# Altium schematic sheet LPEDT_revA1_bikeit_MCU_POWER_RESET.SchDoc(LPEDT_revA1_bikeit_MCU_POWER_RESET)
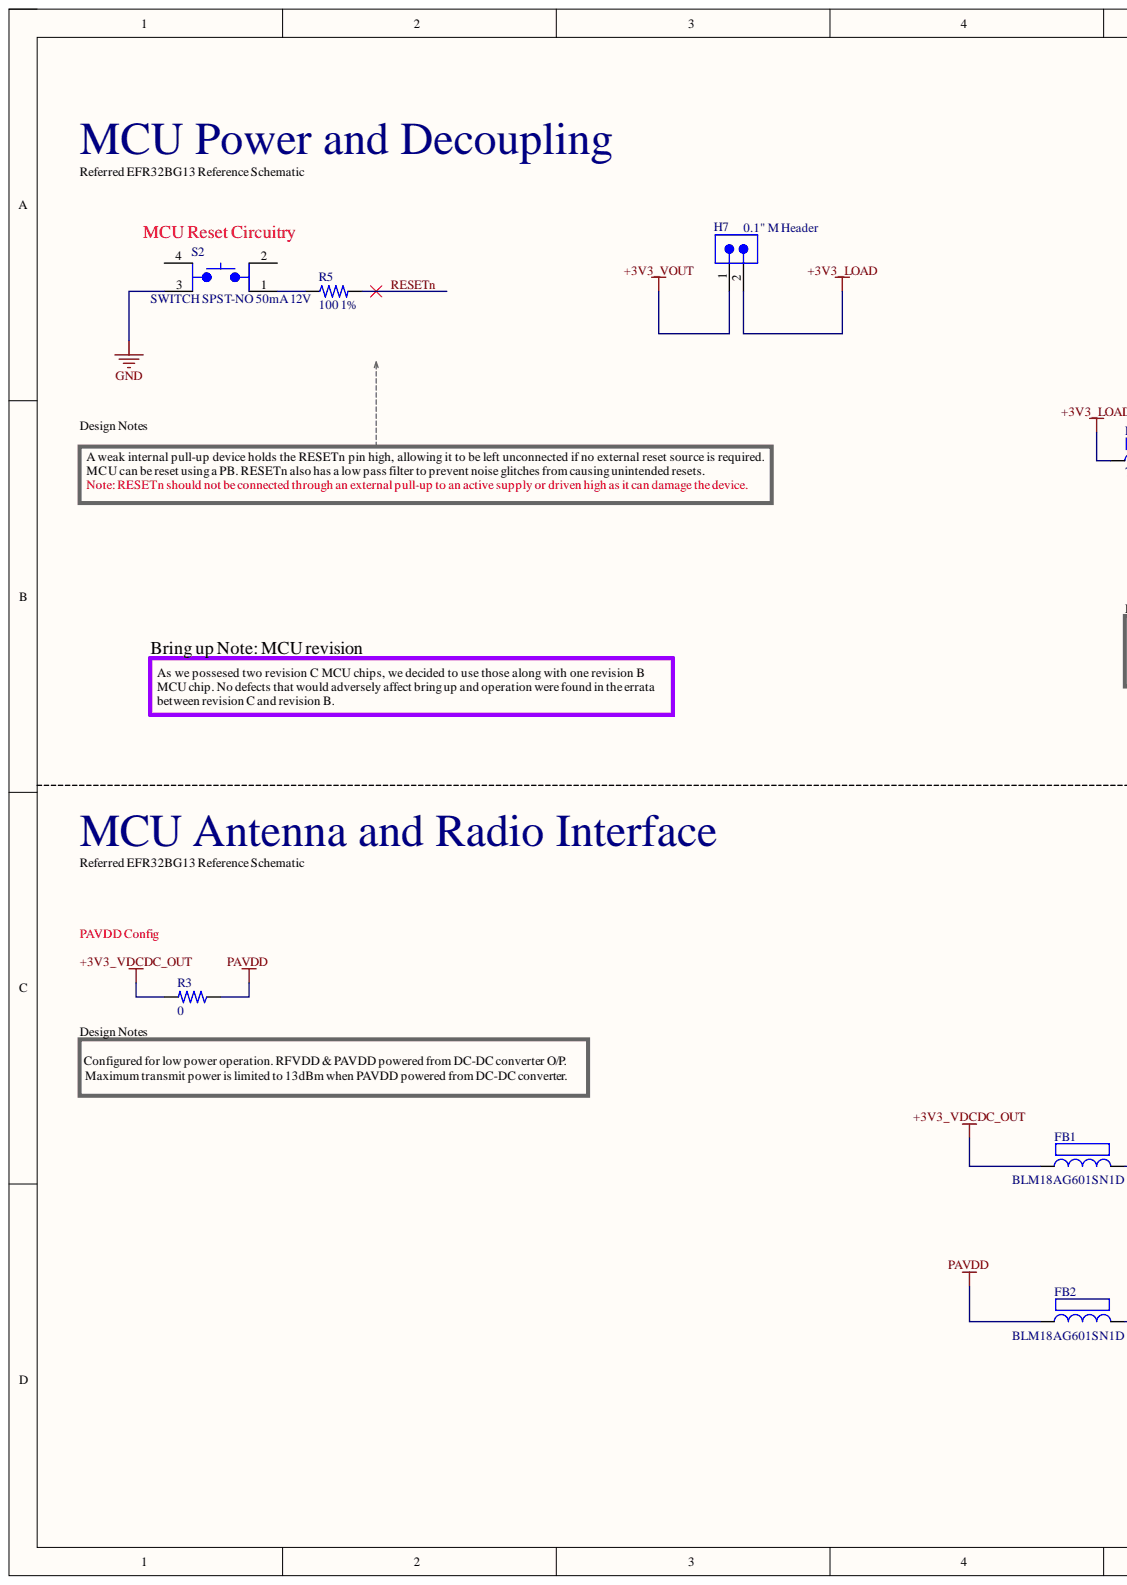
[diagram p0: LPEDT_revA1_bikeit_MCU_POWER_RESET.SchDoc, left]
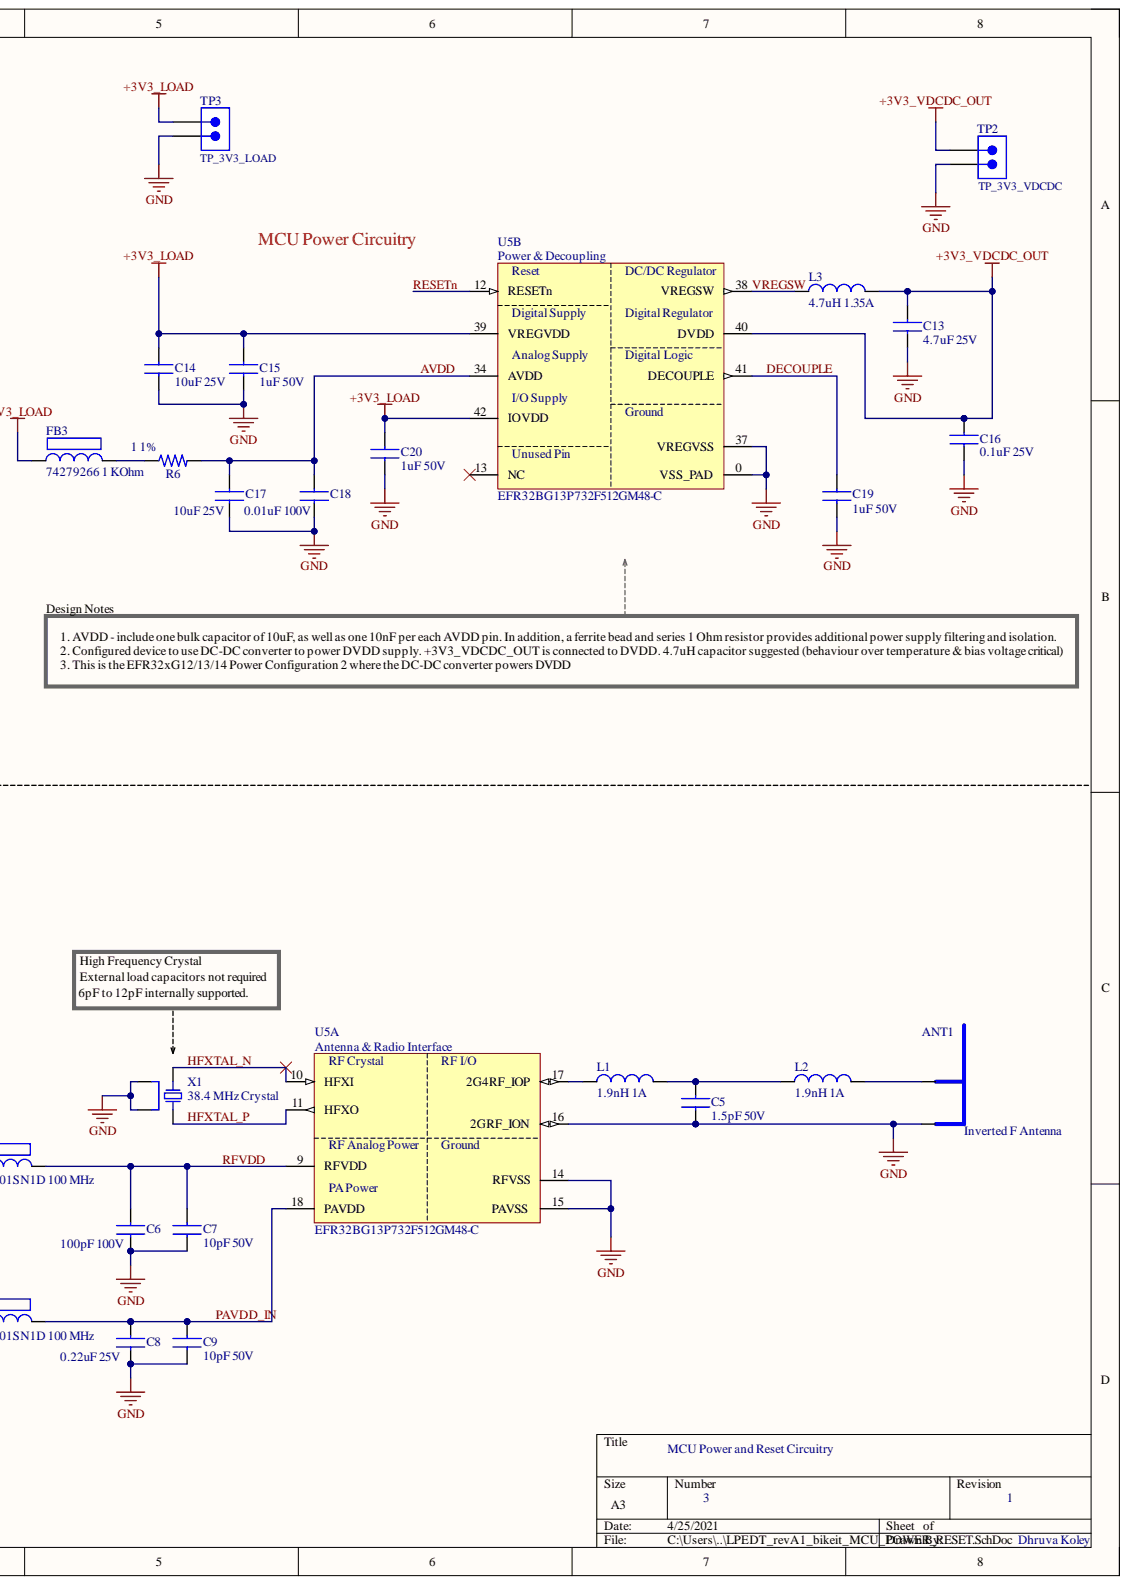
[diagram p1: LPEDT_revA1_bikeit_MCU_POWER_RESET.SchDoc, right]

## Parts
ANT1  Inverted F Antenna  [MIFA]
C5  1.5pF 50V  [CAP_0403_1.00mm*0.50mm]
C6  100pF 100V  Capacitance: 100pF  Voltage Rating: 100V  [CAP_0603_1.60mm*0.80mm]
C7  10pF 50V  Capacitance: 10pF  Voltage: 50V  [CAP_0603_1.60mm*0.80mm]
C8  0.22uF 25V  Voltage Rating: 25V  [CAP_0603_1.60mm*0.80mm]
C9  10pF 50V  Capacitance: 10pF  Voltage: 50V  [CAP_0603_1.60mm*0.80mm]
C13  4.7uF 25V  Capacitance: 4.7uF  [CAP_0603_1.60mm*0.80mm]
C14  10uF 25V  Capacitance: 10uF  [CAP_0805_2MM]
C15  1uF 50V  Capacitance: 1uF  Voltage Rating: 50V  [CAP_0603_1.60mm*0.80mm]
C16  0.1uF 25V  [CAP_0603_1.60mm*0.80mm]
C17  10uF 25V  Capacitance: 10uF  Voltage Rating: 25V  [CAP_0805_2MM]
C18  0.01uF 100V  Voltage: 100V  [CAP_0603_1.60mm*0.80mm]
C19  1uF 50V  Capacitance: 1uF  Voltage Rating: 50V  [CAP_0603_1.60mm*0.80mm]
C20  1uF 50V  Capacitance: 1uF  Voltage Rating: 50V  [CAP_0603_1.60mm*0.80mm]
FB1  BLM18AG601SN1D 100 MHz  [FB_Chip2PinSM127P600-8N]
FB2  BLM18AG601SN1D 100 MHz  [FB_Chip2PinSM127P600-8N]
FB3  74279266 1 KOhm  [FB_0603_1.60mm*0.80mm]
H7  0.1" M Header  [2-PIN_MALE_HEADER_01-IN]
L1  1.9nH 1A  [IND_0402]
L2  1.9nH 1A  [IND_0402]
L3  4.7uH 1.35A  Current Rating: 1.35A  Inductance: 4.7uH  [IND_WE-TPC 2828]
R3  0  [RES_C1005X04N]
R5  100 1%  Tolerance: 1%  [RES_0603_1608X06]
R6  1 1%  Resistance Tolerance: 1%  [RES_0603_1608X06]
S2  SWITCH SPST-NO 50mA 12V  [SW_TL3305AF260QG]
TP2  TP_3V3_VDCDC  [TP10x_Probe]
TP3  TP_3V3_LOAD  [TP10x_Probe]
U5  EFR32BG13P732F512GM48-C  [MCU_QFN50P700X700X90_HS-49N]  (parts A,B)
X1  38.4 MHz Crystal  [SMD_4 CRYSTAL]

## Nets
+3V3_LOAD: C14.1 C15.1 C20.1 FB3.1 H7.2 TP3.1 U5.39(VREGVDD) U5.42(IOVDD)
+3V3_VDCDC_OUT: C13.1 C16.1 FB1.1 L3.2 R3.2 TP2.1 U5.40(DVDD)
+3V3_VOUT: H7.1
GND: ANT1.GND C5.2 C6.2 C7.2 C8.2 C9.2 C13.2 C14.2 C15.2 C16.2 C17.2 C18.2 C19.2 C20.2 S2.3 TP2.2 TP3.2 U5.0(VSS_PAD) U5.14(RFVSS) U5.15(PAVSS) U5.16(2GRF_ION) U5.37(VREGVSS) X1.2 X1.4
PAVDD: FB2.1 R3.1
AVDD: C17.1 C18.1 R6.2 U5.34(AVDD)
DECOUPLE: C19.1 U5.41(DECOUPLE)
HFXTAL_N: U5.10(HFXI) X1.3
HFXTAL_P: U5.11(HFXO) X1.1
PAVDD_IN: C8.1 C9.1 FB2.2 U5.18(PAVDD)
RESETn: R5.2 U5.12(RESETn)
RFVDD: C6.1 C7.1 FB1.2 U5.9(RFVDD)
VREGSW: L3.1 U5.38(VREGSW)
NetANT1_FEED: ANT1.FEED L2.2
NetC5_1: C5.1 L1.2 L2.1
NetFB3_2: FB3.2 R6.1
NetL1_1: L1.1 U5.17(2G4RF_IOP)
NetR5_1: R5.1 S2.1

Not connected: S2 2 4; U5 13(NC)

## Library data of the parts
ANT1 = Inverted F Antenna: Inverted F antenna for BT; Component Kind: Net Tie; Library Name: LPEDT_revA1_bikeit_IL.IntLib
C5 = 500R07S1R5BV4T: 1.5pF ±0.1pF 50V Ceramic Capacitor C0G, NP0 0402 (1005 Metric); Manufacturer: Johanson Technology Inc.; Component Kind: Standard; Manufacturer Part Number: 500R07S1R5BV4T; RoHS Compliant: Yes; Supplier 1: Digi-Key; Supplier Part Number 1: 712-1270-1-ND; Library Name: LPEDT_revA1_bikeit_IL.IntLib
C6 = GRM1885C2A101FA01D: Multilayer Ceramic Capacitors MLCC - SMD/SMT 100pF 100Volts C0G 0.01; Manufacturer: Murata; Tolerance: 1%; Case Code (Imperial): 0603; Case Code (Metric): 1608; Case/Package: 0603; Category: Ceramic Capacitors; Component Kind: Standard; ComponentLink1Description: https://datasheet.ciiva.com/29537/partnumbering-e-01-29537864.pdf?src-supplier=Digi-Key; ComponentLink1URL: https://datasheet.ciiva.com/29537/partnumbering-e-01-29537864.pdf?src-supplier=Digi-Key; Depth: 0.8mm; Dielectric: C0G; Height: 0.8mm; Length: 1.6mm; Manufacturer Part Number: GRM1885C2A101FA01D; Max Operating Temperature: 125°C; Min Operating Temperature: -55°C; Mount: Surface Mount; Package Quantity: 4000; Packaging: Tape and Reel; Pricing 1: 4000=0.03696, 8000=0.03511, 12000=0.03326, 28000=0.03142 (USD); Radiation Hardening: No; RoHS: Yes; Stock 1: 40000; Supplier 1: Digi-Key; Supplier Part Number 1: 490-14623-1-ND; Thickness: 0.035inch; Voltage Rating (DC): 100V; Width: 0.031inch; Library Name: LPEDT_revA1_bikeit_IL.IntLib
C7 C9 = GRM1885C1H100FA01D: Cap Ceramic 10pF 50V C0G 1% SMD 0603 125C T/R; Manufacturer: Murata; Temperature Coefficient: 30ppm/°C; Case Code (Imperial): 0603; Case Code (Metric): 1608; Case/Package: 0603; Category: Ceramic Capacitors; Component Kind: Standard; ComponentLink1Description: https://datasheet.ciiva.com/2989/c02e-2989424.pdf?src-supplier=Avnet; ComponentLink1URL: https://datasheet.ciiva.com/2989/c02e-2989424.pdf?src-supplier=Avnet; ComponentLink2Description: https://datasheet.ciiva.com/166/partnumbering-e-01-166098.pdf?src-supplier=Digi-Key; ComponentLink2URL: https://datasheet.ciiva.com/166/partnumbering-e-01-166098.pdf?src-supplier=Digi-Key; ComponentLink3Description: https://datasheet.ciiva.com/45259/partnumbering-e-01-45259517.pdf?src-supplier=Digi-Key; ComponentLink3URL: https://datasheet.ciiva.com/45259/partnumbering-e-01-45259517.pdf?src-supplier=Digi-Key; ComponentLink4Description: https://datasheet.ciiva.com/17453/c02e-17453134.pdf?src-supplier=Avnet+Europe; ComponentLink4URL: https://datasheet.ciiva.com/17453/c02e-17453134.pdf?src-supplier=Avnet+Europe; Construction: Flat; Depth: 0.8mm; Dielectric: C0G; Height: 0.8mm; Lead Free: Lead Free; Length: 1.6mm; Manufacturer Part Number: GRM1885C1H100FA01D; Material: Ceramic; Max Operating Temperature: 125°C; Min Operating Temperature: -55°C; Mount: Surface Mount; Package Quantity: 4000; Packaging: Tape and Reel; Pricing 1: 1=0.15000, 10=0.11000, 50=0.06240, 100=0.05170 (USD); Radiation Hardening: No; RoHS: Yes; RoHS Compliant: Yes; Series: GRM; Stock 1: 160; Supplier 1: Digi-Key; Supplier Part Number 1: 490-9713-1-ND; Termination: SMD/SMT; Thickness: 0.035inch; Tolerance: 1%; Voltage Rating: 50V; Voltage Rating (DC): 50V; Width: 0.8mm; Library Name: LPEDT_revA1_bikeit_IL.IntLib
C8 = GRM188R71E224KA88D: Capacitor 220 nF 25 VDC PU=4000 ST, GRM188R71E224KA88D, Murata; Capacitance: 220nF; Dielectric: X7R; Material: Ceramic; Tolerance: 10%; Voltage: 50V; Case Code (Imperial): 0603; Case Code (Metric): 1608; Case/Package: 0603; Category: Ceramic Capacitors; Component Kind: Standard; ComponentLink1Description: https://datasheet.ciiva.com/5411/product-5411362.pdf?src-supplier=Mouser; ComponentLink1URL: https://datasheet.ciiva.com/5411/product-5411362.pdf?src-supplier=Mouser; ComponentLink2Description: https://datasheet.ciiva.com/11786/0900766b807a1cab-11786308.pdf?src-supplier=RSComponents; ComponentLink2URL: https://datasheet.ciiva.com/11786/0900766b807a1cab-11786308.pdf?src-supplier=RSComponents; ComponentLink3Description: https://datasheet.ciiva.com/6068/1784408-6068393.pdf?src-supplier=Farnell; ComponentLink3URL: https://datasheet.ciiva.com/6068/1784408-6068393.pdf?src-supplier=Farnell; ComponentLink4Description: https://datasheet.ciiva.com/133/grm188r71e224ka88-01-133883.pdf?src-supplier=Digi-Key; ComponentLink4URL: https://datasheet.ciiva.com/133/grm188r71e224ka88-01-133883.pdf?src-supplier=Digi-Key; ComponentLink5Description: https://datasheet.ciiva.com/133/grm188r71e224ka88-01-133884.pdf?src-supplier=Digi-Key; ComponentLink5URL: https://datasheet.ciiva.com/133/grm188r71e224ka88-01-133884.pdf?src-supplier=Digi-Key; ComponentLink6Description: https://datasheet.ciiva.com/3777/1531grm188r71e224ka8823-3777688.pdf?src-supplier=Arrow+Electronics; ComponentLink6URL: https://datasheet.ciiva.com/3777/1531grm188r71e224ka8823-3777688.pdf?src-supplier=Arrow+Electronics; ComponentLink7Description: https://datasheet.ciiva.com/29202/451619-da-01-en-vs-kondensator-0603-29202002.pdf?src-supplier=Conrad; ComponentLink7URL: https://datasheet.ciiva.com/29202/451619-da-01-en-vs-kondensator-0603-29202002.pdf?src-supplier=Conrad; ComponentLink8Description: https://datasheet.ciiva.com/14116/nmgrm-data-en-14116629.pdf?src-supplier=Distrelec; ComponentLink8URL: https://datasheet.ciiva.com/14116/nmgrm-data-en-14116629.pdf?src-supplier=Distrelec; ComponentLink9Description: https://datasheet.ciiva.com/16425/product-16425866.pdf?src-supplier=Future+Electronics; ComponentLink9URL: https://datasheet.ciiva.com/16425/product-16425866.pdf?src-supplier=Future+Electronics; ComponentLink10Description: https://datasheet.ciiva.com/22703/1784408-22703338.pdf?src-supplier=Element14; ComponentLink10URL: https://datasheet.ciiva.com/22703/1784408-22703338.pdf?src-supplier=Element14; ComponentLink11Description: https://datasheet.ciiva.com/7937/1784408-7937645.pdf?src-supplier=Element14; ComponentLink11URL: https://datasheet.ciiva.com/7937/1784408-7937645.pdf?src-supplier=Element14; ComponentLink12Description: https://datasheet.ciiva.com/48436/nmgrm-data-en-48436687.pdf?src-supplier=Distrelec; ComponentLink12URL: https://datasheet.ciiva.com/48436/nmgrm-data-en-48436687.pdf?src-supplier=Distrelec; Depth: 0.8mm; Height: 0.8mm; Lead Free: Lead Free; Length: 1.6mm; Manufacturer: Murata; Manufacturer Part Number: GRM188R71E224KA88D; Max Operating Temperature: 125°C; Min Operating Temperature: -55°C; Mount: Surface Mount; Number of Pins: 2; Package Quantity: 1; Packaging: Tape and Reel; Pricing 1: 1=0.20000, 10=0.13700, 50=0.08240, 100=0.06960, 500=0.04760, 1000=0.04210 (USD); Radiation Hardening: No; REACH SVHC: No SVHC; RoHS: Yes; RoHS Compliant: Yes; Stock 1: 208118; Supplier 1: Digi-Key; Supplier Part Number 1: 490-3290-1-ND; Termination: SMD/SMT; Thickness: 0.035inch; Voltage Rating (DC): 25V; Width: 0.8mm; Library Name: LPEDT_revA1_bikeit_IL.IntLib
C13 = GRM188R61E475KE11D: Cap Ceramic 0603 4.7 uF 25 V ±10 % Tolerance X5R SMT Multilayer Ceramic Capacitor; Manufacturer: Murata; Tolerance: 10%; Case Code (Imperial): 0603; Case Code (Metric): 1608; Case/Package: 0603; Category: Ceramic Capacitors; Component Kind: Standard; ComponentLink1Description: https://datasheet.ciiva.com/46444/1769893-46444982.pdf?src-supplier=Element14; ComponentLink1URL: https://datasheet.ciiva.com/46444/1769893-46444982.pdf?src-supplier=Element14; ComponentLink2Description: https://datasheet.ciiva.com/46444/1769893-46444983.pdf?src-supplier=Element14; ComponentLink2URL: https://datasheet.ciiva.com/46444/1769893-46444983.pdf?src-supplier=Element14; ComponentLink3Description: https://datasheet.ciiva.com/14891/partnumbering-e-01-14891103.pdf?src-supplier=Digi-Key; ComponentLink3URL: https://datasheet.ciiva.com/14891/partnumbering-e-01-14891103.pdf?src-supplier=Digi-Key; ComponentLink4Description: https://datasheet.ciiva.com/14891/partnumbering-e-01-14891102.pdf?src-supplier=Digi-Key; ComponentLink4URL: https://datasheet.ciiva.com/14891/partnumbering-e-01-14891102.pdf?src-supplier=Digi-Key; Depth: 0.8mm; Dielectric: X5R; Height: 0.8mm; Lead Free: Lead Free; Length: 1.6mm; Manufacturer Part Number: GRM188R61E475KE11D; Material: Ceramic; Max Operating Temperature: 85°C; Min Operating Temperature: -55°C; Mount: Surface Mount; Package Quantity: 4000; Packaging: Cut Tape; Pricing 1: 1=0.36000, 10=0.24700, 50=0.17280, 100=0.14820, 500=0.11112, 1000=0.09384 (USD); REACH SVHC: No SVHC; RoHS: Yes; RoHS Compliant: Yes; Series: GRM; Stock 1: 307528; Supplier 1: Digi-Key; Supplier Part Number 1: 490-7203-1-ND; Thickness: 0.031inch; Voltage: 25V; Voltage Rating: 25V; Voltage Rating (DC): 25V; Width: 0.031inch; Library Name: LPEDT_revA1_bikeit_IL.IntLib
C14 C17 = GRM21BR61E106KA73K: CAP CER 10UF 25V X5R 0805; Manufacturer: Murata; Tolerance: 10%; Case/Package: 0805; Category: Ceramic Capacitors; Component Kind: Standard; ComponentLink1Description: http://www.mouser.com/ds/2/281/c02e-2905.pdf?src-supplier=Mouser; ComponentLink1URL: http://www.mouser.com/ds/2/281/c02e-2905.pdf?src-supplier=Mouser; ComponentLink2Description: http://search.murata.co.jp/Ceramy/image/img/A01X/G101/ENG/GRM21BR61E106KA73-01.pdf?src-supplier=Digi-Key; ComponentLink2URL: http://search.murata.co.jp/Ceramy/image/img/A01X/G101/ENG/GRM21BR61E106KA73-01.pdf?src-supplier=Digi-Key; ComponentLink3Description: https://search.murata.co.jp/Ceramy/image/img/A01X/G101/ENG/GRM21BR61E106KA73-01.pdf?src-supplier=Digi-Key; ComponentLink3URL: https://search.murata.co.jp/Ceramy/image/img/A01X/G101/ENG/GRM21BR61E106KA73-01.pdf?src-supplier=Digi-Key; Dielectric: X5R; Length: 0.079inch; Manufacturer Part Number: GRM21BR61E106KA73K; Max Operating Temperature: 85°C; Min Operating Temperature: -55°C; Mount: Surface Mount; Package Quantity: 10000; Packaging: Tape and Reel; Pricing 1: 1=0.23000, 10=0.15700, 50=0.09400, 100=0.07940, 500=0.05434, 1000=0.04807, 2500=0.04598, 5000=0.04180 (USD); RoHS: Yes; RoHS Compliant: Yes; Stock 1: 79269; Supplier 1: Digi-Key; Supplier Part Number 1: 490-16824-1-ND; Thickness: 0.055inch; Voltage Rating (DC): 25V; Weight: 0.000335oz; Width: 0.049inch; Library Name: LPEDT_revA1_bikeit_IL.IntLib
  C14: Voltage Rating: 25V
C15 C19 C20 = GRT188R61H105KE13D: CAP, MLCC, AEC-Q200, X5R, 1uF, 50V, 0603; Manufacturer: Murata; Tolerance: 10%; Case Code (Imperial): 0603; Case Code (Metric): 1608; Case/Package: 0603; Category: Ceramic Capacitors; Component Kind: Standard; ComponentLink1Description: http://www.farnell.com/datasheets/2165959.pdf?src-supplier=Farnell; ComponentLink1URL: http://www.farnell.com/datasheets/2165959.pdf?src-supplier=Farnell; ComponentLink2Description: http://search.murata.co.jp/Ceramy/image/img/A01X/G101/ENG/GRT188R61H105KE13-01.pdf?src-supplier=Digi-Key; ComponentLink2URL: http://search.murata.co.jp/Ceramy/image/img/A01X/G101/ENG/GRT188R61H105KE13-01.pdf?src-supplier=Digi-Key; ComponentLink3Description: https://search.murata.co.jp/Ceramy/image/img/A01X/G101/ENG/GRT188R61H105KE13-01.pdf?src-supplier=Digi-Key; ComponentLink3URL: https://search.murata.co.jp/Ceramy/image/img/A01X/G101/ENG/GRT188R61H105KE13-01.pdf?src-supplier=Digi-Key; ComponentLink4Description: http://www.farnell.com/datasheets/2165959.pdf?src-supplier=Newark; ComponentLink4URL: http://www.farnell.com/datasheets/2165959.pdf?src-supplier=Newark; Dielectric: X5R; Length: 0.063inch; Manufacturer Part Number: GRT188R61H105KE13D; Max Operating Temperature: 85°C; Min Operating Temperature: -55°C; Mount: Surface Mount; Packaging: Tape and Reel; Pricing 1: 1=0.26000, 10=0.17900, 50=0.10720, 100=0.09060, 500=0.06198, 1000=0.05483 (USD); Ratings: AEC-Q200; RoHS: Yes; RoHS Compliant: Yes; Stock 1: 645268; Supplier 1: Digi-Key; Supplier Part Number 1: 490-12330-1-ND; Thickness: 0.035inch; Voltage Rating (DC): 50V; Width: 0.031inch; Library Name: LPEDT_revA1_bikeit_IL.IntLib
C16 = GCJ188R71E104KA12D: CAP CER 0.1UF 25V X7R 0603; Manufacturer: Murata; Capacitance: 100nF; Tolerance: 10%; Case Code (Imperial): 0603; Case Code (Metric): 1608; Case/Package: 0603; Category: Ceramic Capacitors; Component Kind: Standard; ComponentLink1Description: http://search.murata.co.jp/Ceramy/image/img/A01X/G101/ENG/GCJ188R71E104KA12-01.pdf?src-supplier=Digi-Key; ComponentLink1URL: http://search.murata.co.jp/Ceramy/image/img/A01X/G101/ENG/GCJ188R71E104KA12-01.pdf?src-supplier=Digi-Key; Features: Soft Termination; Manufacturer Part Number: GCJ188R71E104KA12D; Max Operating Temperature: 125°C; Min Operating Temperature: -55°C; Mount: Surface Mount; Packaging: Tape and Reel; Pricing 1: 1=0.21000, 10=0.14900, 50=0.08480, 100=0.07030, 500=0.04928, 1000=0.04080 (USD); Ratings: AEC-Q200; RoHS: Yes; RoHS Compliant: Yes; Stock 1: 1672; Supplier 1: Mouser; Supplier Part Number 1: 81-GCJ188R71E104KA2D; Voltage Rating: 25V; Library Name: LPEDT_revA1_bikeit.SchLib
C18 = GCM188R72A103KA37D: Multilayer Ceramic Capacitor, AEC-Q200 GCM Series, 0.01 F, 10%, X7R, 100 V RoHS Compliant: Yes; Manufacturer: Murata; Manufacturer Part Number: GCM188R72A103KA37J; Capacitance: 10nF; Case Code (Imperial): 0603; Case Code (Metric): 1608; Case/Package: 0603; Category: Ceramic Capacitors; Component Kind: Standard; ComponentLink1Description: https://datasheet.ciiva.com/132/product-132907.pdf?src-supplier=Digi-Key; ComponentLink1URL: https://datasheet.ciiva.com/132/product-132907.pdf?src-supplier=Digi-Key; ComponentLink2Description: https://datasheet.ciiva.com/132/product-132909.pdf?src-supplier=Digi-Key; ComponentLink2URL: https://datasheet.ciiva.com/132/product-132909.pdf?src-supplier=Digi-Key; ComponentLink3Description: https://datasheet.ciiva.com/16497/product-16497251.pdf?src-supplier=Future+Electronics; ComponentLink3URL: https://datasheet.ciiva.com/16497/product-16497251.pdf?src-supplier=Future+Electronics; ComponentLink4Description: https://datasheet.ciiva.com/18183/getdatasheetpartid-178890-18183651.pdf?src-supplier=Verical; ComponentLink4URL: https://datasheet.ciiva.com/18183/getdatasheetpartid-178890-18183651.pdf?src-supplier=Verical; ComponentLink5Description: https://datasheet.ciiva.com/132/product-132908.pdf?src-supplier=Digi-Key; ComponentLink5URL: https://datasheet.ciiva.com/132/product-132908.pdf?src-supplier=Digi-Key; ComponentLink6Description: https://datasheet.ciiva.com/11786/0900766b80ef92d0-11786108.pdf?src-supplier=RSComponents; ComponentLink6URL: https://datasheet.ciiva.com/11786/0900766b80ef92d0-11786108.pdf?src-supplier=RSComponents; ComponentLink7Description: https://datasheet.ciiva.com/11619/product-11619719.pdf?src-supplier=Avnet; ComponentLink7URL: https://datasheet.ciiva.com/11619/product-11619719.pdf?src-supplier=Avnet; Construction: Flat; Depth: 0.8mm; Dielectric: X7R; Height: 0.8mm; Lead Free: Lead Free; Length: 1.6mm; Max Operating Temperature: 125°C; Min Operating Temperature: -55°C; Mount: Surface Mount; Package Quantity: 1; Packaging: Tape and Reel; Pricing 1: 1=0.10000, 10=0.07400, 50=0.04060, 100=0.03310, 500=0.02364, 1000=0.01857 (USD); Radiation Hardening: No; Ratings: AEC-Q200; REACH SVHC: No SVHC; RoHS: Yes; RoHS Compliant: Yes; Series: GCM; Stock 1: 112779; Supplier 1: Digi-Key; Supplier Part Number 1: 490-8028-1-ND; Termination: SMD/SMT; Thickness: 0.035inch; Tolerance: 10%; Voltage Rating: 100V; Voltage Rating (DC): 100V; Width: 0.8mm; Library Name: LPEDT_revA1_bikeit.SchLib
FB1 FB2 = BLM18AG601SN1D: Ferrite Bead, 0603 / 1608, 600 ohm, 500 mA, Série BLM18A, 0.38 ohm, ± 25%; Manufacturer: Murata; Current Rating: 500mA; DC Resistance (DCR): 380mR; Frequency: 100MHz; Impedance: 600R; Material: Steel,Ferrite; Number of Circuits: 1; Shielding: Unshielded; Case Code (Imperial): 0603; Case Code (Metric): 1608; Case/Package: 0603; Category: Inductors; Component Kind: Standard; ComponentLink1Description: https://datasheet.ciiva.com/19460/1844766-19460213.pdf?src-supplier=Jameco; ComponentLink1URL: https://datasheet.ciiva.com/19460/1844766-19460213.pdf?src-supplier=Jameco; ComponentLink2Description: https://datasheet.ciiva.com/29347/442309-da-01-en-chip-drossel-0603-600r-500ma-1-6x0-8mm-29347900.pdf?src-supplier=Conrad; ComponentLink2URL: https://datasheet.ciiva.com/29347/442309-da-01-en-chip-drossel-0603-600r-500ma-1-6x0-8mm-29347900.pdf?src-supplier=Conrad; ComponentLink3Description: https://datasheet.ciiva.com/41449/001172228-da-01-en-drossel-0603-600r-1-6x0-8mm-4000st-41449517.pdf?src-supplier=Conrad; ComponentLink3URL: https://datasheet.ciiva.com/41449/001172228-da-01-en-drossel-0603-600r-1-6x0-8mm-4000st-41449517.pdf?src-supplier=Conrad; ComponentLink4Description: https://datasheet.ciiva.com/1012/l0110s0100blm18a-1012017.pdf?src-supplier=Digi-Key; ComponentLink4URL: https://datasheet.ciiva.com/1012/l0110s0100blm18a-1012017.pdf?src-supplier=Digi-Key; ComponentLink5Description: https://datasheet.ciiva.com/1012/l0110s0100blm18a-1012018.pdf?src-supplier=Digi-Key; ComponentLink5URL: https://datasheet.ciiva.com/1012/l0110s0100blm18a-1012018.pdf?src-supplier=Digi-Key; ComponentLink6Description: https://datasheet.ciiva.com/1012/l0110s0100blm18a-1012019.pdf?src-supplier=Digi-Key; ComponentLink6URL: https://datasheet.ciiva.com/1012/l0110s0100blm18a-1012019.pdf?src-supplier=Digi-Key; ComponentLink7Description: https://datasheet.ciiva.com/1466/1756147-1466933.pdf?src-supplier=Element14; ComponentLink7URL: https://datasheet.ciiva.com/1466/1756147-1466933.pdf?src-supplier=Element14; ComponentLink8Description: https://datasheet.ciiva.com/1466/1756147-1466934.pdf?src-supplier=Element14; ComponentLink8URL: https://datasheet.ciiva.com/1466/1756147-1466934.pdf?src-supplier=Element14; ComponentLink9Description: https://datasheet.ciiva.com/16210/l0110s0100blm18a-16210006.pdf?src-supplier=Future+Electronics; ComponentLink9URL: https://datasheet.ciiva.com/16210/l0110s0100blm18a-16210006.pdf?src-supplier=Future+Electronics; ComponentLink10Description: https://datasheet.ciiva.com/3081/l0110s0100blm18a-3081613.pdf?src-supplier=Avnet; ComponentLink10URL: https://datasheet.ciiva.com/3081/l0110s0100blm18a-3081613.pdf?src-supplier=Avnet; Core Material: Ferrite; DC Current: 500mA; Depth: 0.8mm; Height: 0.8mm; Lead Free: Lead Free; Length: 1.6mm; Manufacturer Part Number: BLM18AG601SN1D; Max Current Rating: 500mA; Max DC Current: 500mA; Max Operating Temperature: 125°C; Military Standard: Not; Min Operating Temperature: -55°C; Number of Lines: 1; Number of Pins: 2; Package Quantity: 1; Packaging: Tape and Reel; Pricing 1: 1=0.10000, 10=0.07200, 25=0.06560, 50=0.05900, 100=0.04320, 250=0.03144, 500=0.02882, 1000=0.02489 (USD); Radiation Hardening: No; REACH SVHC: No SVHC; RoHS: Yes; RoHS Compliant: Yes; Stock 1: 826617; Supplier 1: Digi-Key; Supplier Part Number 1: 490-1014-1-ND; Termination: SMD/SMT; Test Frequency: 100MHz; Tolerance: 25%; Weight: 7.1E-05oz; Width: 0.8mm; Library Name: LPEDT_revA1_bikeit_IL.IntLib
FB3 = 74279266: Ferrite Bead, 0603 [1608 Metric], 1 kohm, 200 mA, WE-CBF Series, 0.6 ohm, ± 25%; Manufacturer: Wurth Electronics; Current Rating: 200mA; DC Resistance (DCR): 600mR; Impedance: 1kR; Inductance: 1.8nH; Number of Lines: 1; Shielding: Unshielded; Test Frequency: 100MHz; Case Code (Imperial): 0603; Case Code (Metric): 1608; Case/Package: SMD/SMT; Category: Ferrite Beads and Chips; Component Kind: Standard; ComponentLink1Description: https://datasheet.ciiva.com/14634/0900766b801ef1d0-14634816.pdf?src-supplier=Allied+Electronics; ComponentLink1URL: https://datasheet.ciiva.com/14634/0900766b801ef1d0-14634816.pdf?src-supplier=Allied+Electronics; ComponentLink2Description: https://datasheet.ciiva.com/23763/74279266-23763009.pdf?src-supplier=Newark; ComponentLink2URL: https://datasheet.ciiva.com/23763/74279266-23763009.pdf?src-supplier=Newark; ComponentLink3Description: https://datasheet.ciiva.com/11799/0900766b801ef1d0-11799352.pdf?src-supplier=RSComponents; ComponentLink3URL: https://datasheet.ciiva.com/11799/0900766b801ef1d0-11799352.pdf?src-supplier=RSComponents; ComponentLink4Description: https://datasheet.ciiva.com/14971/74279266-14971192.pdf?src-supplier=Digi-Key; ComponentLink4URL: https://datasheet.ciiva.com/14971/74279266-14971192.pdf?src-supplier=Digi-Key; ComponentLink5Description: https://datasheet.ciiva.com/12395/74279266-221135-12395453.pdf?src-supplier=Mouser; ComponentLink5URL: https://datasheet.ciiva.com/12395/74279266-221135-12395453.pdf?src-supplier=Mouser; ComponentLink6Description: https://datasheet.ciiva.com/1466/1705901-1466509.pdf?src-supplier=Element14; ComponentLink6URL: https://datasheet.ciiva.com/1466/1705901-1466509.pdf?src-supplier=Element14; ComponentLink7Description: https://datasheet.ciiva.com/22711/1705901-22711422.pdf?src-supplier=Element14; ComponentLink7URL: https://datasheet.ciiva.com/22711/1705901-22711422.pdf?src-supplier=Element14; ComponentLink8Description: https://datasheet.ciiva.com/48698/001087059-da-01-ml-we-cbf-smd-ferrit-74279266-de-en-48698427.pdf?src-supplier=Conrad; ComponentLink8URL: https://datasheet.ciiva.com/48698/001087059-da-01-ml-we-cbf-smd-ferrit-74279266-de-en-48698427.pdf?src-supplier=Conrad; Core Material: Ferrite; Depth: 0.8mm; Height: 0.8mm; Lead Free: Lead Free; Length: 1.6mm; Manufacturer Part Number: 74279266; Max Current Rating: 200mA; Max DC Current: 200mA; Max Operating Temperature: 125°C; Min Operating Temperature: -55°C; Packaging: Tape and Reel; Pricing 1: 1=0.17000, 50=0.16800, 100=0.15500, 500=0.14800, 1000=0.14100, 2000=0.13400 (USD); REACH SVHC: Unknown; RoHS: Yes; RoHS Compliant: Yes; Series: WE-CBF; Stock 1: 55585; Supplier 1: Digi-Key; Supplier Part Number 1: 732-4484-1-ND; Termination: SMD/SMT; Tolerance: 25%; Width: 0.8mm; Library Name: LPEDT_revA1_bikeit_IL.IntLib
H7 = PRPC002SAAN-RC: CONN HEADER VERT 2POS 2.54MM; Manufacturer: Sullins; Connector Type: Header, Unshrouded, Breakaway; Number of Positions: 2; Number of Positions Loaded: All; Online Catalog: 0.100" (2.54mm) Pitch Connector Headers; Other Names: S9510E-02  S9510E-02-ND; Pitch: 0.100" (2.54mm); Category: Connectors, Interconnects; Color: Black; Component Kind: Standard; ComponentLink1Description: https://media.digikey.com/PDF/Data%20Sheets/Sullins%20PDFs/xRxCzzzSxxN-RC_ST_11635-B.pdf?src-supplier=Digi-Key; ComponentLink1URL: https://media.digikey.com/PDF/Data%20Sheets/Sullins%20PDFs/xRxCzzzSxxN-RC_ST_11635-B.pdf?src-supplier=Digi-Key; Contact Finish: Gold; Contact Finish Thickness: Flash; Contact Mating Length: 0.230" (5.84mm); Contact Type: Male Pin; Family: Rectangular Connectors - Headers, Male Pins; Fastening Type: -; Features: -; Manufacturer Part Number: PRPC002SAAN-RC; Mounting Type: Through Hole; Number of Rows: 1; Packaging: Bulk; Pricing 1: 1=0.08, 10=0.073, 25=0.054, 50=0.0464, 100=0.0449, 250=0.0387, 500=0.0372 (USD); Row Spacing: -; Series: -; Standard Package: 1; Stock 1: 42739; Supplier 1: Digi-Key; Supplier Part Number 1: S1011EC-02-ND; Termination: Solder; Library Name: LPEDT_revA1_bikeit_IL.IntLib
L1 L2 = LQG15WZ1N9S02D: 1.9nH Unshielded Multilayer Inductor 1A 50mOhm Max 0402 (1005 Metric); Category: Fixed Inductors; Component Kind: Standard; RoHS: No; Supplier 1: Digi-Key; Supplier Part Number 1: 490-LQG15WZ1N9S02DCT-ND; Library Name: LPEDT_revA1_bikeit_IL.IntLib
L3 = 744025004: Fixed Inductors WE-TPC 2828 4.7uH 1.35A .1Ohm; Manufacturer: Wurth Electronics; Core Material: Ferrite; DC Resistance (DCR): 120mR; Inductance Tolerance: 20%; Saturation Current: 1.7A; Self Resonant Frequency: 84MHz; Series Resistance: 100mR; Shielding: Shielded; Test Frequency: 100kHz; Case/Package: Nonstandard; Category: Fixed Inductors; Component Kind: Standard; ComponentLink1Description: https://datasheet.ciiva.com/6690/744025004-6690389.pdf?src-supplier=Digi-Key; ComponentLink1URL: https://datasheet.ciiva.com/6690/744025004-6690389.pdf?src-supplier=Digi-Key; ComponentLink2Description: https://datasheet.ciiva.com/11803/0900766b80f886b6-11803593.pdf?src-supplier=RSComponents; ComponentLink2URL: https://datasheet.ciiva.com/11803/0900766b80f886b6-11803593.pdf?src-supplier=RSComponents; ComponentLink3Description: https://datasheet.ciiva.com/4954/744025004-76625-4954718.pdf?src-supplier=Mouser; ComponentLink3URL: https://datasheet.ciiva.com/4954/744025004-76625-4954718.pdf?src-supplier=Mouser; ComponentLink4Description: https://datasheet.ciiva.com/6690/744025004-6690390.pdf?src-supplier=Digi-Key; ComponentLink4URL: https://datasheet.ciiva.com/6690/744025004-6690390.pdf?src-supplier=Digi-Key; ComponentLink5Description: https://datasheet.ciiva.com/14123/744025004-eng-tds-14123830.pdf?src-supplier=Distrelec; ComponentLink5URL: https://datasheet.ciiva.com/14123/744025004-eng-tds-14123830.pdf?src-supplier=Distrelec; ComponentLink6Description: https://datasheet.ciiva.com/6690/744025004-6690391.pdf?src-supplier=Digi-Key; ComponentLink6URL: https://datasheet.ciiva.com/6690/744025004-6690391.pdf?src-supplier=Digi-Key; ComponentLink7Description: https://datasheet.ciiva.com/7650/1243426-7650570.pdf?src-supplier=Element14; ComponentLink7URL: https://datasheet.ciiva.com/7650/1243426-7650570.pdf?src-supplier=Element14; Depth: 2.8mm; Height: 2.8mm; Height - Seated (Max): 0.118inch; Length: 2.8mm; Manufacturer Part Number: 744025004; Max DC Current: 1.35A; Max Operating Temperature: 125°C; Min Operating Temperature: -40°C; Mount: Surface Mount; Number of Pins: 2; Package Quantity: 550; Packaging: Cut Tape; Pricing 1: 1=1.54000, 10=1.48000, 50=1.36000, 100=1.13000, 250=1.02000 (USD); REACH SVHC: Unknown; Resistor Type: Wirewound; RMS Current (Irms): 1.35A; RoHS: Yes; Stock 1: 11294; Supplier 1: Digi-Key; Supplier Part Number 1: 732-2615-1-ND; Termination: SMD/SMT; Tolerance: 20%; Width: 0.11inch; Library Name: LPEDT_revA1_bikeit_IL.IntLib
R3 = RMCF0402ZT0R00: RES SMD 0 OHM JUMPER 1/16W 0402; Manufacturer: Stackpole Electronics; Current Rating: 1A; Max Power Dissipation: 63mW; Resistance: 0R; Working Voltage: 50V; Case Code (Imperial): 0402; Case Code (Metric): 1005; Case/Package: 0402; Category: Chip SMD Resistors; Component Kind: Standard; ComponentLink1Description: https://datasheet.ciiva.com/26835/getdatasheetpartid-409751-26835612.pdf?src-supplier=Verical; ComponentLink1URL: https://datasheet.ciiva.com/26835/getdatasheetpartid-409751-26835612.pdf?src-supplier=Verical; Construction: Rectangular; Contact Plating: Tin,Matte; Depth: 0.5mm; Features: Automotive AEC-Q200; Height: 0.016inch; Lead Free: Lead Free; Length: 0.039inch; Manufacturer Part Number: RMCF0402ZT0R00; Max Operating Temperature: 155°C; Military Standard: Not; Min Operating Temperature: -55°C; Mount: Surface Mount; Number of Terminations: 2; Packaging: Tape and Reel; Power Rating: 63mW; Pricing 1: 1=0.10000, 10=0.01000, 25=0.00720, 50=0.00560, 100=0.00410, 250=0.00316, 500=0.00252, 1000=0.00186, 5000=0.00133 (USD); Radiation Hardening: No; Resistor Type: Thick Film; RoHS: Yes; RoHS Compliant: Yes; Series: RMCF; Stock 1: 5982991; Supplier 1: Digi-Key; Technology: THICK FILM; Width: 0.02inch; Library Name: LPEDT_revA1_bikeit_IL.IntLib
R5 = RMCF0603FT100R: RES SMD 100 1%; Manufacturer: Stackpole Electronics; Max Power Dissipation: 100mW; Resistance: 100R; Temperature Coefficient: 100ppm/°C; Case Code (Imperial): 0603; Case Code (Metric): 1608; Case/Package: 0603; Category: Chip SMD Resistors; Component Kind: Standard; ComponentLink1Description: https://datasheet.ciiva.com/15215/getdatasheetpartid-360413-15215981.pdf?src-supplier=Verical; ComponentLink1URL: https://datasheet.ciiva.com/15215/getdatasheetpartid-360413-15215981.pdf?src-supplier=Verical; Construction: Rectangular; Contact Plating: Tin,Matte; Depth: 0.8mm; Features: Automotive AEC-Q200; Height: 0.022inch; Lead Free: Lead Free; Length: 1.55mm; Manufacturer Part Number: RMCF0603FT100R; Max Operating Temperature: 155°C; Military Standard: Not; Min Operating Temperature: -55°C; Mount: Surface Mount; Number of Terminations: 2; Packaging: Tape and Reel; Power Rating: 100mW; Pricing 1: 1=0.10000, 10=0.01600, 100=0.00650, 1000=0.00292, 2500=0.00253 (USD); Radiation Hardening: No; Resistor Type: Thick Film; RoHS: Yes; RoHS Compliant: Yes; Series: RMCF; Size Code: 0603; Stock 1: 1363320; Supplier 1: Digi-Key; Supplier Part Number 1: RMCF0603FT100RCT-ND; Width: 0.031inch; Library Name: LPEDT_revA1_bikeit_IL.IntLib
R6 = ERJ-3RQF1R0V: RES SMD 1 OHM 1% 1/10W 0603; Manufacturer: Panasonic; Max Power Dissipation: 100mW; Resistance: 1R; Temperature Coefficient: 200ppm/°C; Case Code (Imperial): 0603; Case Code (Metric): 1608; Case/Package: 0603; Category: Chip SMD Resistors; Component Kind: Standard; ComponentLink1Description: https://datasheet.ciiva.com/11810/0900766b80eefa12-11810728.pdf?src-supplier=RSComponents; ComponentLink1URL: https://datasheet.ciiva.com/11810/0900766b80eefa12-11810728.pdf?src-supplier=RSComponents; ComponentLink2Description: https://datasheet.ciiva.com/16396/aoa0000ce3-16396837.pdf?src-supplier=Future+Electronics; ComponentLink2URL: https://datasheet.ciiva.com/16396/aoa0000ce3-16396837.pdf?src-supplier=Future+Electronics; ComponentLink3Description: https://datasheet.ciiva.com/28691/391509-28691973.pdf?src-supplier=Element14; ComponentLink3URL: https://datasheet.ciiva.com/28691/391509-28691973.pdf?src-supplier=Element14; ComponentLink4Description: https://datasheet.ciiva.com/16784/70068852-16784839.pdf?src-supplier=Allied+Electronics; ComponentLink4URL: https://datasheet.ciiva.com/16784/70068852-16784839.pdf?src-supplier=Allied+Electronics; ComponentLink5Description: https://datasheet.ciiva.com/9117/aoa0000ce3-9117368.pdf?src-supplier=Avnet; ComponentLink5URL: https://datasheet.ciiva.com/9117/aoa0000ce3-9117368.pdf?src-supplier=Avnet; ComponentLink6Description: https://datasheet.ciiva.com/24165/aoa0000ce3-72189-24165336.pdf?src-supplier=Mouser; ComponentLink6URL: https://datasheet.ciiva.com/24165/aoa0000ce3-72189-24165336.pdf?src-supplier=Mouser; ComponentLink7Description: https://datasheet.ciiva.com/30060/6aoa0000ce3-30060648.pdf?src-supplier=Onlinecomponents.com; ComponentLink7URL: https://datasheet.ciiva.com/30060/6aoa0000ce3-30060648.pdf?src-supplier=Onlinecomponents.com; ComponentLink8Description: https://datasheet.ciiva.com/6138/391509-6138777.pdf?src-supplier=Farnell; ComponentLink8URL: https://datasheet.ciiva.com/6138/391509-6138777.pdf?src-supplier=Farnell; ComponentLink9Description: https://datasheet.ciiva.com/16766/70068852-16766778.pdf?src-supplier=Allied+Electronics; ComponentLink9URL: https://datasheet.ciiva.com/16766/70068852-16766778.pdf?src-supplier=Allied+Electronics; ComponentLink10Description: https://datasheet.ciiva.com/16853/aoa0000ce3-16853436.pdf?src-supplier=Avnet+Europe; ComponentLink10URL: https://datasheet.ciiva.com/16853/aoa0000ce3-16853436.pdf?src-supplier=Avnet+Europe; ComponentLink11Description: https://datasheet.ciiva.com/43455/391509-43455868.pdf?src-supplier=Farnell; ComponentLink11URL: https://datasheet.ciiva.com/43455/391509-43455868.pdf?src-supplier=Farnell; ComponentLink12Description: https://datasheet.ciiva.com/28547/391509-28547230.pdf?src-supplier=Element14; ComponentLink12URL: https://datasheet.ciiva.com/28547/391509-28547230.pdf?src-supplier=Element14; Construction: Rectangular; Contact Plating: Tin; Depth: 0.8mm; Height: 0.45mm; Lead Free: Lead Free; Length: 1.6mm; Manufacturer Part Number: ERJ-3RQF1R0V; Max Operating Temperature: 125°C; Military Standard: Not; Min Operating Temperature: -55°C; Mount: Surface Mount; Number of Pins: 2; Number of Terminations: 2; Package Quantity: 5000; Packaging: Tape and Reel; Power Rating: 100mW; Pricing 1: 1=0.16000, 10=0.13600, 25=0.09920, 50=0.07200, 100=0.05330, 250=0.04092, 500=0.03224, 1000=0.02232 (USD); Radiation Hardening: No; REACH SVHC: No SVHC; Resistor Type: Thick Film; RoHS: Yes; RoHS Compliant: Yes; Series: ERJ; Size Code: 0603; Stock 1: 151763; Supplier 1: Digi-Key; Supplier Part Number 1: P1.0AJCT-ND; Technology: THICK FILM; Termination: SMD/SMT; Tolerance: 1%; Weight: 7.1E-05oz; Width: 0.8mm; Library Name: LPEDT_revA1_bikeit_IL.IntLib
S2 = TL3305AF260QG: SWITCH TACTILE SPST-NO 50MA 12V; Manufacturer: E-Switch; Actuator Type: Standard; Illumination Type: Non-Illuminated; Mechanical Life: 200000Cycles; Operating Force: 260gf; Switch Function: Off-Mom; Switch Travel: 0.2mm; Throw Configuration: SPST; Category: Tactile Switches; Component Kind: Standard; ComponentLink1Description: http://www.farnell.com/datasheets/2554191.pdf?src-supplier=Farnell; ComponentLink1URL: http://www.farnell.com/datasheets/2554191.pdf?src-supplier=Farnell; ComponentLink2Description: http://www.farnell.com/datasheets/2554191.pdf?src-supplier=Newark; ComponentLink2URL: http://www.farnell.com/datasheets/2554191.pdf?src-supplier=Newark; ComponentLink3Description: http://www.farnell.com/datasheets/2554191.pdf?src-supplier=Element14; ComponentLink3URL: http://www.farnell.com/datasheets/2554191.pdf?src-supplier=Element14; ComponentLink4Description: http://spec_sheets.e-switch.com/specs/P010471.pdf?src-supplier=Digi-Key; ComponentLink4URL: http://spec_sheets.e-switch.com/specs/P010471.pdf?src-supplier=Digi-Key; ComponentLink5Description: http://spec_sheets.e-switch.com/specs/P010471.pdf?src-supplier=Mouser; ComponentLink5URL: http://spec_sheets.e-switch.com/specs/P010471.pdf?src-supplier=Mouser; ComponentLink6Description: http://spec_sheets.e-switch.com/specs/P010471.pdf?src-supplier=NAC+Semi; ComponentLink6URL: http://spec_sheets.e-switch.com/specs/P010471.pdf?src-supplier=NAC+Semi; Lead Free: Lead Free; Manufacturer Part Number: TL3305AF260QG; Max Operating Temperature: 70°C; Min Operating Temperature: -20°C; Mount: Surface Mount; Packaging: Tape and Reel; Pricing 1: 1=0.18000, 10=0.17700, 25=0.16760, 100=0.15250, 250=0.14336, 500=0.13116, 1000=0.10980 (USD); RoHS: No; Stock 1: 70002; Supplier 1: Digi-Key; Supplier Part Number 1: EG5353CT-ND; Termination: Gull Wing; Library Name: LPEDT_revA1_bikeit_IL.IntLib
TP2 TP3 = Test Point: Component Kind: Standard; Library Name: LPEDT_revA1_bikeit_IL.IntLib
U5 = EFR32BG13P732F512GM48-C: BLUE GECKO QFN48 2.4 GHZ 512KB 6; Manufacturer: Silicon Labs; Category: RF Receivers, Transceivers; Component Kind: Standard; ComponentLink1Description: http://www.farnell.com/datasheets/2813683.pdf?src-supplier=Farnell; ComponentLink1URL: http://www.farnell.com/datasheets/2813683.pdf?src-supplier=Farnell; Manufacturer Part Number: EFR32BG13P732F512GM48-C; Pricing 1: 1=7.61000, 10=6.79200, 25=6.11320, 80=5.56988, 230=5.02643, 440=4.51023, 945=3.80380 (USD); RoHS: No; Supplier 1: Digi-Key; Supplier Part Number 1: 336-4109-ND; Library Name: LPEDT_revA1_bikeit_IL.IntLib
X1 = CX2016DB38400D0FLJCC: CRYSTAL 38.4000MHZ 8PF SMD; Manufacturer: Kyocera AVX; ESR (Equivalent Series Resistance): 60R; Frequency Stability: 15ppm; Frequency Tolerance: 10ppm; Case/Package: SMD/SMT; Category: Crystals; Component Kind: Standard; ComponentLink1Description: https://datasheet.ciiva.com/26992/cx2016db-cs-e-26992341.pdf?src-supplier=Mouser; ComponentLink1URL: https://datasheet.ciiva.com/26992/cx2016db-cs-e-26992341.pdf?src-supplier=Mouser; ComponentLink2Description: https://datasheet.ciiva.com/29631/cx2016db-wl-e-29631639.pdf?src-supplier=Digi-Key; ComponentLink2URL: https://datasheet.ciiva.com/29631/cx2016db-wl-e-29631639.pdf?src-supplier=Digi-Key; ComponentLink3Description: https://datasheet.ciiva.com/29631/cx2016db-wl-e-29631640.pdf?src-supplier=Digi-Key; ComponentLink3URL: https://datasheet.ciiva.com/29631/cx2016db-wl-e-29631640.pdf?src-supplier=Digi-Key; ComponentLink4Description: https://datasheet.ciiva.com/29631/cx2016db-wl-e-29631641.pdf?src-supplier=Digi-Key; ComponentLink4URL: https://datasheet.ciiva.com/29631/cx2016db-wl-e-29631641.pdf?src-supplier=Digi-Key; Frequency: 38.4MHz; Height: 0.018inch; Lead Pitch: 1.4mm; Length: 0.079inch; Load Capacitance: 8pF; Manufacturer Part Number: CX2016DB38400D0FLJCC; Max Operating Temperature: 85°C; Min Operating Temperature: -30°C; Mount: Surface Mount; Package Quantity: 3000; Packaging: Tape and Reel; Pricing 1: 1=0.65000, 10=0.57500, 50=0.53760, 100=0.47500, 500=0.45000, 1000=0.37500 (USD); RoHS: Yes; Stock 1: 63853; Supplier 1: Digi-Key; Supplier Part Number 1: 1253-1119-1-ND; Termination: SMD/SMT; Tolerance: 10ppm; Width: 1.6mm; Library Name: LPEDT_revA1_bikeit_IL.IntLib
